# Altium schematic sheet Sheet3.SchDoc(Sheet3)
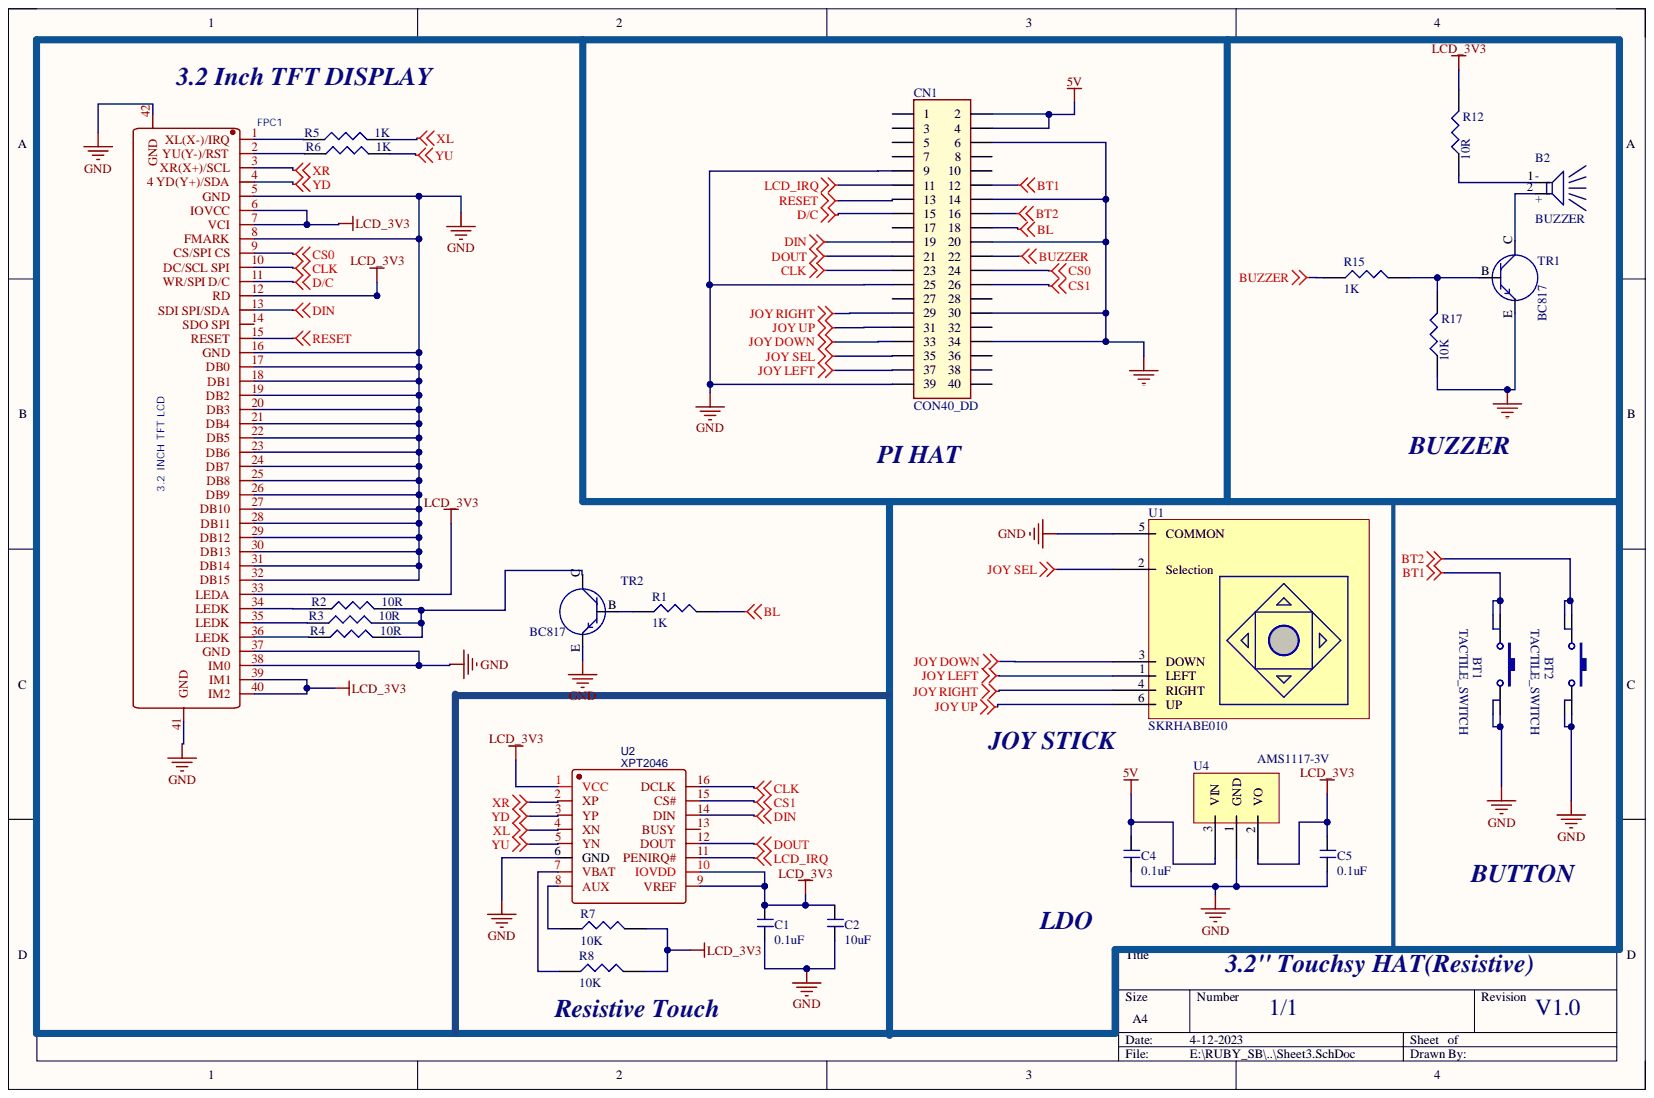
[diagram p0: Sheet3.SchDoc]

## Parts
B2  BUZZER  [SMD BUZZ]
BT1  TACTILE_SWITCH  [SKRPACE010]
BT2  TACTILE_SWITCH  [SKRPACE010]
C1  0.1uF  [C_0603]
C2  10uF  [C_0603]
C4  0.1uF  [C_0603]
C5  0.1uF  [C_0603]
CN1  CON40_DD  [SMD/TH 2X20P 2.54MM]  (value not drawn)
FPC1  3.2 INCH TFT LCD  [3.2 TFT LCD_RES]
R1  1K  [R_0603]
R2  10R  [R_0603]
R3  10R  [R_0603]
R4  10R  [R_0603]
R5  1K  [R_0603]
R6  1K  [R_0603]
R7  10K  [R_0603]
R8  10K  [R_0603]
R12  10R  [R_0603]
R15  1K  [R_0603]
R17  10K  [R_0603]
TR1  BC817  [SOT23]
TR2  BC817  [SOT23]
U1  SKRHABE010  [JOY_STICK]
U2  XPT2046  [TSSOP-16]
U4  AMS1117-3V  [AMS1117_3V3]

## Nets
5V: C4.2 CN1.2 CN1.4 U4.3
BL: CN1.18 R1.1
BT1: BT1.1 BT1.2 CN1.12
BT2: BT2.1 BT2.2 CN1.16
BUZZER: CN1.22 R15.1
CLK: CN1.23 FPC1.10(DC/SCL_SPI) U2.16(DCLK)
CS0: CN1.24 FPC1.9(CS/SPI_CS)
CS1: CN1.26 U2.15(CS#)
D/C: CN1.15 FPC1.11(WR/SPI_D/C)
DIN: CN1.19 FPC1.13(SDI_SPI/SDA) U2.14(DIN)
DOUT: CN1.21 U2.12(DOUT)
GND: BT1.3 BT1.4 BT2.3 BT2.4 C1.1 C2.1 C4.1 C5.1 CN1.6 CN1.9 CN1.14 CN1.20 CN1.25 CN1.30 CN1.34 CN1.39 FPC1.5(GND) FPC1.8(FMARK) FPC1.16(GND) FPC1.17(DB0) FPC1.18(DB1) FPC1.19(DB2) FPC1.20(DB3) FPC1.21(DB4) FPC1.22(DB5) FPC1.23(DB6) FPC1.24(DB7) FPC1.25(DB8) FPC1.26(DB9) FPC1.27(DB10) FPC1.28(DB11) FPC1.29(DB12) FPC1.30(DB13) FPC1.31(DB14) FPC1.32(DB15) FPC1.37(GND) FPC1.38(IM0) FPC1.41(GND) FPC1.42(GND) R17.1 TR1.E TR2.E U1.5(COMMON) U2.6(GND) U4.1
JOY DOWN: CN1.33 U1.3(DOWN)
JOY LEFT: CN1.37 U1.1(LEFT)
JOY RIGHT: CN1.29 U1.4(RIGHT)
JOY SEL: CN1.35 U1.2(Selection)
JOY UP: CN1.31 U1.6(UP)
LCD_3V3: C1.2 C2.2 C5.2 FPC1.6(IOVCC) FPC1.7(VCI) FPC1.12(RD) FPC1.33(LEDA) FPC1.39(IM1) FPC1.40(IM2) R7.1 R8.1 R12.2 U2.1(VCC) U2.9(VREF) U2.10(IOVDD) U4.2
LCD_IRQ: CN1.11 U2.11(PENIRQ#)
RESET: CN1.13 FPC1.15(RESET)
XL: R5.2 U2.4(XN)
XR: FPC1.3(XR(X+)/SCL) U2.2(XP)
YD: FPC1.4(4_YD(Y+)/SDA) U2.3(YP)
YU: R6.2 U2.5(YN)
NetB2_1: B2.1 R12.1
NetB2_2: B2.2 TR1.C
NetFPC1_1: FPC1.1(XL(X-)/IRQ) R5.1
NetFPC1_2: FPC1.2(YU(Y-)/RST) R6.1
NetFPC1_34: FPC1.34(LEDK) R2.1
NetFPC1_35: FPC1.35(LEDK) R3.1
NetFPC1_36: FPC1.36(LEDK) R4.1
NetR1_2: R1.2 TR2.B
NetR2_2: R2.2 R3.2 R4.2 TR2.C
NetR7_2: R7.2 U2.8(AUX)
NetR8_2: R8.2 U2.7(VBAT)
NetR15_2: R15.2 R17.2 TR1.B

Not connected: CN1 1 3 5 7 8 10 17 27 28 32 36 38 40; FPC1 14(SDO_SPI); U2 13(BUSY)

## Library data of the parts
B2 = BUZZER PIEZO: Component Kind: Standard; Library Name: dxp_pasl_lib.IntLib
C1 C2 C4 C5 = CAP_NONPOLAR: Component Kind: Standard; Library Name: dxp_pasl_lib.IntLib
FPC1 = FPC-40P: Component Kind: Standard; Library Name: MIX.SchLib
R1-R8 R12 R15 R17 = RES: Component Kind: Standard; Library Name: dxp_pasl_lib.IntLib
TR1 TR2 = TRANSISTER_NPN: Component Kind: Standard; Library Name: dxp_pasl_lib.IntLib
U1 = SKRHABE010: SMD 5-way Tactile Switches RoHS #C139794; Component Kind: Standard; Library Name: dxp_pasl_lib.IntLib
U2 = XPT2046: BOM_Manufacturer: XPT(矽普特); BOM_JLCPCB Part Class: Extended Part; BOM_Manufacturer Part: XPT2046; BOM_Supplier: LCSC; BOM_Supplier Part: C19076; Component Kind: Standard; spicePre: U; spiceSymbolName: XPT2046
U4 = AMS1117: Component Kind: Standard; Library Name: dxp_pasl_lib.IntLib
BT1 BT2 = TACTILE_SWITCH: Component Kind: Standard; Library Name: dxp_pasl_lib.IntLib
CN1 = CON40_DD: Component Kind: Standard; Library Name: dxp_pasl_lib.IntLib
